# Altium schematic sheet XDS100V3.SchDoc
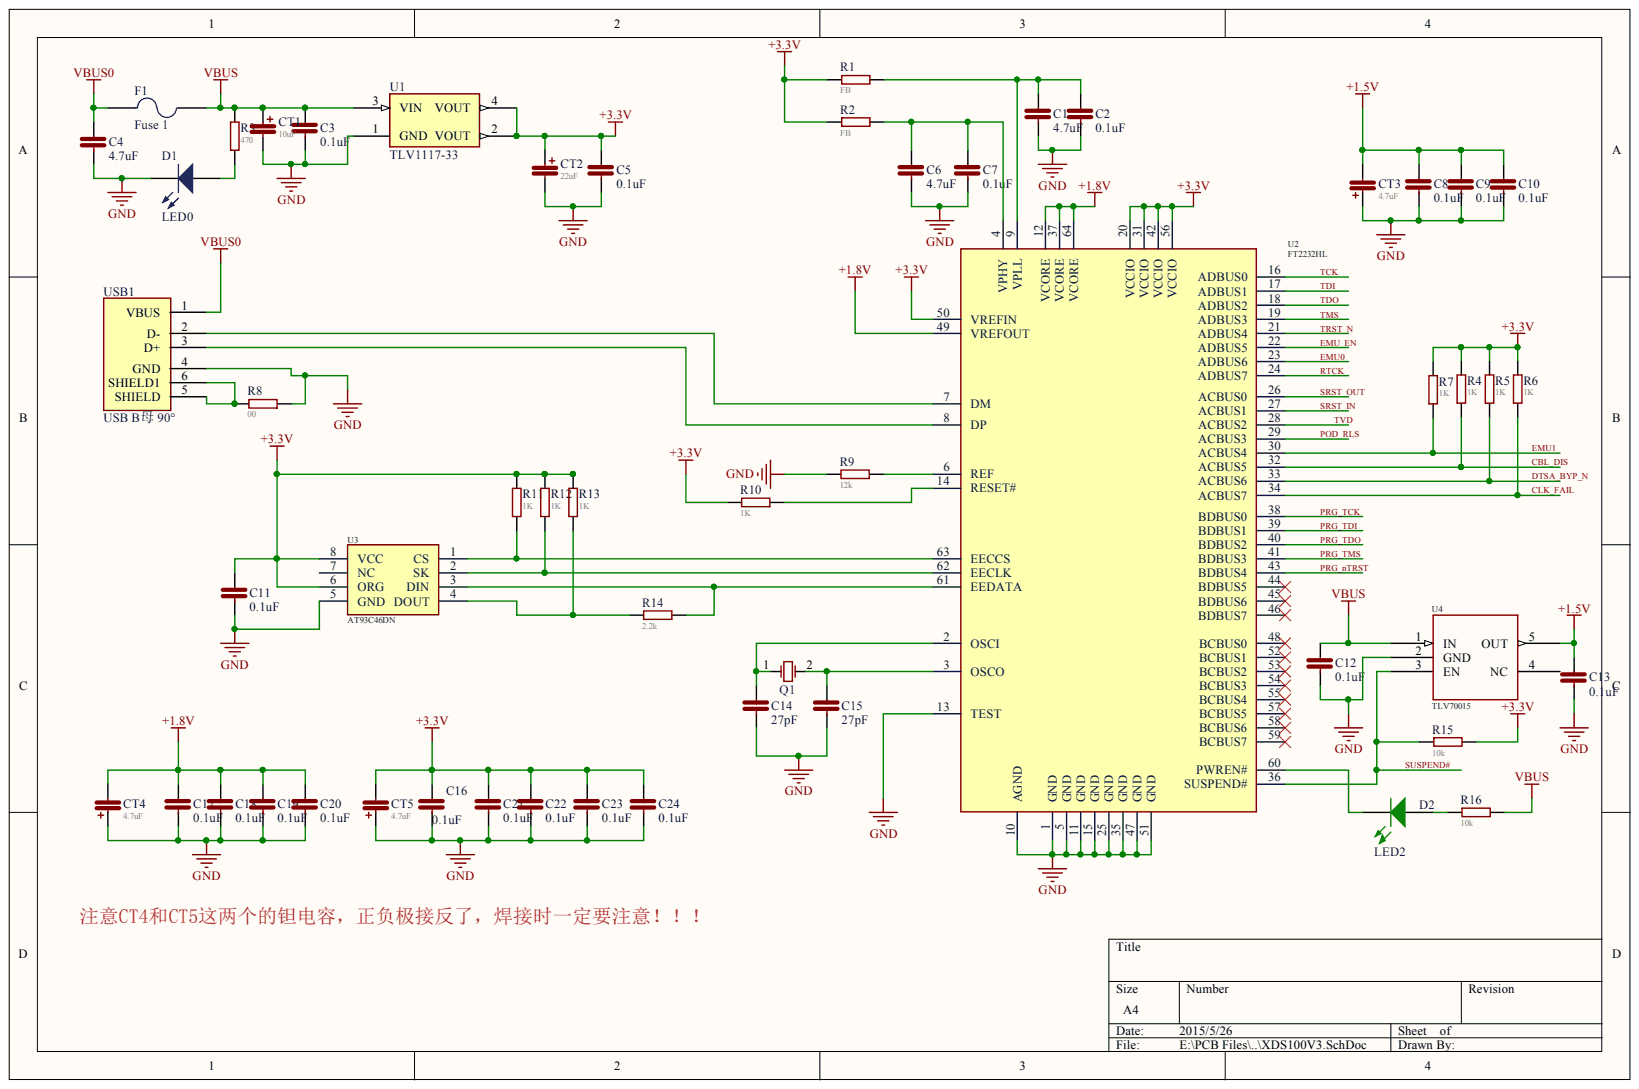
[diagram p0: XDS100V3.SchDoc]

## Parts
C1  CAPACITOR NON-POL_1  [C-0805]  (value not drawn)
C2  CAPACITOR NON-POL_1  [C-0805]  (value not drawn)
C3  CAPACITOR NON-POL_1  [C-0805]  (value not drawn)
C4  CAPACITOR NON-POL_1  [C-0805]  (value not drawn)
C5  CAPACITOR NON-POL_1  [C-0805]  (value not drawn)
C6  CAPACITOR NON-POL_1  [C-0805]  (value not drawn)
C7  CAPACITOR NON-POL_1  [C-0805]  (value not drawn)
C8  CAPACITOR NON-POL_1  [C-0805]  (value not drawn)
C9  CAPACITOR NON-POL_1  [C-0805]  (value not drawn)
C10  CAPACITOR NON-POL_1  [C-0805]  (value not drawn)
C11  CAPACITOR NON-POL_1  [C-0805]  (value not drawn)
C12  CAPACITOR NON-POL_1  [C-0805]  (value not drawn)
C13  CAPACITOR NON-POL_1  [C-0805]  (value not drawn)
C14  CAPACITOR NON-POL_1  [C-0805]  (value not drawn)
C15  CAPACITOR NON-POL_1  [C-0805]  (value not drawn)
C16  CAPACITOR NON-POL_1  [C-0805]  (value not drawn)
C17  CAPACITOR NON-POL_1  [C-0805]  (value not drawn)
C18  CAPACITOR NON-POL_1  [C-0805]  (value not drawn)
C19  CAPACITOR NON-POL_1  [C-0805]  (value not drawn)
C20  CAPACITOR NON-POL_1  [C-0805]  (value not drawn)
C21  CAPACITOR NON-POL_1  [C-0805]  (value not drawn)
C22  CAPACITOR NON-POL_1  [C-0805]  (value not drawn)
C23  CAPACITOR NON-POL_1  [C-0805]  (value not drawn)
C24  CAPACITOR NON-POL_1  [C-0805]  (value not drawn)
CT1  Cap Pol0  [A_3216]  (value not drawn)
CT2  Cap Pol0  [A_3216]  (value not drawn)
CT3  Cap Pol0  [A_3216]  (value not drawn)
CT4  Cap Pol0  [A_3216]  (value not drawn)
CT5  Cap Pol0  [A_3216]  (value not drawn)
D1  LED0  [LED-0805]
D2  LED2  [LED-0805]
F1  Fuse 1  [F_1812]
Q1  XTAL  [XTAL_US]  (value not drawn)
R1  Res Semi  [R-0805_N]  (value not drawn)
R2  Res Semi  [R-0805_N]  (value not drawn)
R3  Res Semi  [R-0805_N]  (value not drawn)
R4  Res Semi  [R-0805_N]  (value not drawn)
R5  Res Semi  [R-0805_N]  (value not drawn)
R6  Res Semi  [R-0805_N]  (value not drawn)
R7  Res Semi  [R-0805_N]  (value not drawn)
R8  Res Semi  [R-0805_N]  (value not drawn)
R9  Res Semi  [R-0805_N]  (value not drawn)
R10  Res Semi  [R-0805_N]  (value not drawn)
R11  Res Semi  [R-0805_N]  (value not drawn)
R12  Res Semi  [R-0805_N]  (value not drawn)
R13  Res Semi  [R-0805_N]  (value not drawn)
R14  Res Semi  [R-0805_N]  (value not drawn)
R15  Res Semi  [R-0805_N]  (value not drawn)
R16  Res Semi  [R-0805_N]  (value not drawn)
U1  TLV1117-33  [SOT-223_3]
U2  FT2232HL  [LQPF_PM64]
U3  AT93C46DN  [SOIC-8]
U4  TLV70015  [SC70_DCK5]
USB1  USB B母 90°  [USB-B-F-90]

## Nets
+1.5V: C8.2 C9.2 C10.2 C13.2 CT3.2 U4.5(OUT)
+1.8V: C17.2 C18.2 C19.2 C20.2 CT4.2 U2.12(VCORE) U2.37(VCORE) U2.49(VREFOUT) U2.64(VCORE)
+3.3V: C5.2 C11.2 C16.2 C21.2 C22.2 C23.2 C24.2 CT2.1 CT5.2 R1.1 R2.1 R4.2 R5.2 R6.2 R7.2 R10.2 R11.2 R12.2 R13.2 R15.2 U1.2(VOUT) U1.4(VOUT) U2.20(VCCIO) U2.31(VCCIO) U2.42(VCCIO) U2.50(VREFIN) U2.56(VCCIO) U3.6(ORG) U3.8(VCC)
GND: C1.1 C2.1 C3.1 C4.1 C5.1 C6.1 C7.1 C8.1 C9.1 C10.1 C11.1 C12.1 C13.1 C14.1 C15.1 C16.1 C17.1 C18.1 C19.1 C20.1 C21.1 C22.1 C23.1 C24.1 CT1.2 CT2.2 CT3.1 CT4.1 CT5.1 D1.2 R8.1 R9.2 U1.1(GND) U2.1(GND) U2.5(GND) U2.10(AGND) U2.11(GND) U2.13(TEST) U2.15(GND) U2.25(GND) U2.35(GND) U2.47(GND) U2.51(GND) U3.5(GND) U4.2(GND) USB1.4(GND)
VBUS: C3.2 C12.2 CT1.1 F1.2 R3.2 R16.2 U1.3(VIN) U4.1(IN)
VBUS0: C4.2 F1.1 USB1.1(VBUS)
CBL_DIS: R4.1 U2.32(ACBUS5)
CLK_FAIL: R6.1 U2.34(ACBUS7)
DTSA_BYP_N: R5.1 U2.33(ACBUS6)
EMU0: U2.23(ADBUS6)
EMU1: R7.1 U2.30(ACBUS4)
EMU_EN: U2.22(ADBUS5)
POD_RLS: U2.29(ACBUS3)
PRG_NTRST: U2.43(BDBUS4)
PRG_TCK: U2.38(BDBUS0)
PRG_TDI: U2.39(BDBUS1)
PRG_TDO: U2.40(BDBUS2)
PRG_TMS: U2.41(BDBUS3)
RTCK: U2.24(ADBUS7)
SRST_IN: U2.27(ACBUS1)
SRST_OUT: U2.26(ACBUS0)
SUSPEND#: R15.1 U2.36(SUSPEND#) U4.3(EN)
TCK: U2.16(ADBUS0)
TDI: U2.17(ADBUS1)
TDO: U2.18(ADBUS2)
TMS: U2.19(ADBUS3)
TRST_N: U2.21(ADBUS4)
TVD: U2.28(ACBUS2)
NetC1_2: C1.2 C2.2 R1.2 U2.9(VPLL)
NetC6_2: C6.2 C7.2 R2.2 U2.4(VPHY)
NetC14_2: C14.2 Q1.1 U2.2(OSCI)
NetC15_2: C15.2 Q1.2 U2.3(OSCO)
NetD1_1: D1.1 R3.1
NetD2_1: D2.1 R16.1
NetD2_2: D2.2 U2.60(PWREN#)
NetR8_2: R8.2 USB1.5(SHIELD) USB1.6(SHIELD1)
NetR9_1: R9.1 U2.6(REF)
NetR10_1: R10.1 U2.14(RESET#)
NetR11_1: R11.1 U2.63(EECCS) U3.1(CS)
NetR12_1: R12.1 U2.62(EECLK) U3.2(SK)
NetR13_1: R13.1 R14.2 U3.4(DOUT)
NetR14_1: R14.1 U2.61(EEDATA) U3.3(DIN)
NetU2_7: U2.7(DM) USB1.2(D-)
NetU2_8: U2.8(DP) USB1.3(D+)

Not connected: U2 44(BDBUS5) 45(BDBUS6) 46(BDBUS7) 48(BCBUS0) 52(BCBUS1) 53(BCBUS2) 54(BCBUS3) 55(BCBUS4) 57(BCBUS5) 58(BCBUS6) 59(BCBUS7); U3 7(NC); U4 4(NC)

## Library data of the parts
C1-C24 = CAPACITOR NON-POL_1: Capacitor (Semiconductor SIM Model); Component Kind: Standard; Library Name: MyIntegrated_Library.IntLib
  C1 C4 C6: : 4.7uF
  C2 C3 C5 C7-C13 C16-C24: : 0.1uF
  C14 C15: : 27pF
CT1-CT5 = Cap Pol0: Polarized Capacitor (Surface Mount); Component Kind: Standard; Library Name: MyIntegrated_Library.IntLib
  CT1: : 10uF
  CT2: : 22uF
  CT3-CT5: : 4.7uF
D1 = LED0: Typical BLUE SiC LED; Component Kind: Standard; Library Name: MyIntegrated_Library.IntLib
D2 = LED2: Typical  GREEN GaAs LED; Component Kind: Standard; Library Name: MyIntegrated_Library.IntLib
F1 = Fuse 1: Fuse; Component Kind: Standard; Library Name: MyIntegrated_Library.IntLib
Q1 = XTAL: Crystal Oscillator; Component Kind: Standard; Library Name: MyIntegrated_Library.IntLib
R1-R16 = Res Semi: Semiconductor Resistor; Component Kind: Standard; Library Name: MyIntegrated_Library.IntLib
  R1 R2: : FB
  R3: : 470
  R4-R7 R10-R13: : 1K
  R8: : 00
  R9: : 12k
  R14: : 2.2k
  R15 R16: : 10k
U4 = TLV70015: TLV700系列低压LDO; Component Kind: Standard; Library Name: MyIntegrated_Library.IntLib
USB1 = USB B母 90°: USB B母 90° 铁壳; Component Kind: Standard; Library Name: MyIntegrated_Library.IntLib
U1 = TLV1117-33: Component Kind: Standard; Library Name: MyIntegrated_Library.IntLib
U2 = FT2232HL: Component Kind: Standard; Description: FT2232HL; Library Name: MyIntegrated_Library.IntLib
U3 = AT93C46DN: Component Kind: Standard; Description: AT93C46DN; Library Name: MyIntegrated_Library.IntLib
